# Altium schematic sheet Sheet1.SchDoc
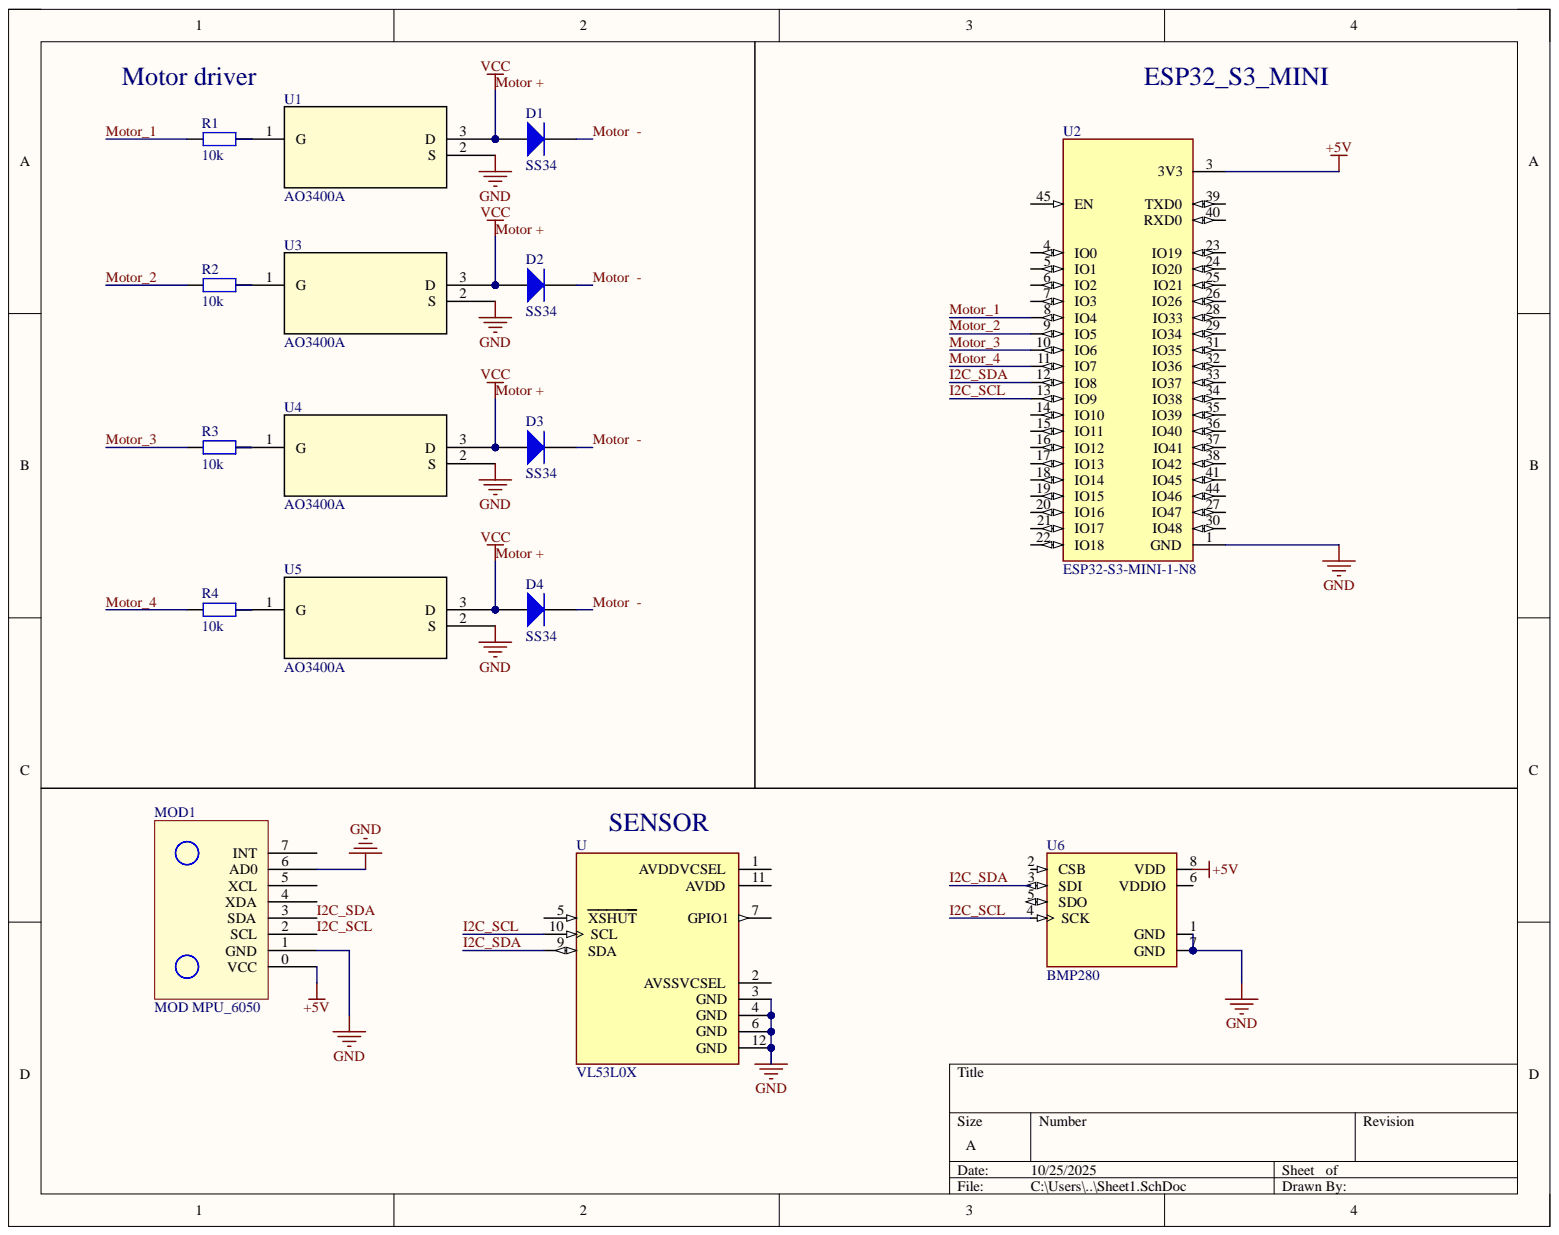
[diagram p0: Sheet1.SchDoc]

## Parts
D1  SS34  [DIODE SMA]
D2  SS34  [DIODE SMA]
D3  SS34  [DIODE SMA]
D4  SS34  [DIODE SMA]
MOD1  MOD MPU_6050  [MOD MPU-6050]
R1  10k  [tro smd 0603]
R2  10k  [tro smd 0603]
R3  10k  [tro smd 0603]
R4  10k  [tro smd 0603]
U  VL53L0X  [SENSOR_VL53L0X]
U1  AO3400A  [SOT-23-3L_AOS]
U2  ESP32-S3-MINI-1-N8  [XCVR_ESP32-S3-MINI-1-N8]
U3  AO3400A  [SOT-23-3L_AOS]
U4  AO3400A  [SOT-23-3L_AOS]
U5  AO3400A  [SOT-23-3L_AOS]
U6  BMP280  [XDCR_BMP280]

## Nets
+5V: MOD1.0(VCC) U2.3(3V3) U6.8(VDD)
GND: MOD1.1(GND) MOD1.6(AD0) U.3(GND) U.4(GND) U.6(GND) U.12(GND) U1.2 U2.1(GND) U3.2 U4.2 U5.2 U6.1(GND) U6.7(GND)
I2C_SCL: MOD1.2(SCL) U.10(SCL) U2.13(IO9) U6.4(SCK)
I2C_SDA: MOD1.3(SDA) U.9(SDA) U2.12(IO8) U6.3(SDI)
Motor  -: D1.2 D2.2 D3.2 D4.2
Motor +: D1.1 D2.1 D3.1 D4.1 U1.3 U3.3 U4.3 U5.3
Motor_1: R1.1 U2.8(IO4)
Motor_2: R2.1 U2.9(IO5)
Motor_3: R3.1 U2.10(IO6)
Motor_4: R4.1 U2.11(IO7)
NetR1_2: R1.2 U1.1
NetR2_2: R2.2 U3.1
NetR3_2: R3.2 U4.1
NetR4_2: R4.2 U5.1

Not connected: MOD1 4(XDA) 5(XCL) 7(INT); U 1(AVDDVCSEL) 2(AVSSVCSEL) 5(XSHUT) 7(GPIO1) 11(AVDD); U2 4(IO0) 5(IO1) 6(IO2) 7(IO3) 14(IO10) 15(IO11) 16(IO12) 17(IO13) 18(IO14) 19(IO15) 20(IO16) 21(IO17) 22(IO18) 23(IO19) 24(IO20) 25(IO21) 26(IO26) 27(IO47) 28(IO33) 29(IO34) 30(IO48) 31(IO35) 32(IO36) 33(IO37) 34(IO38) 35(IO39) 36(IO40) 37(IO41) 38(IO42) 39(TXD0) 40(RXD0) 41(IO45) 44(IO46) 45(EN); U6 2(CSB) 5(SDO) 6(VDDIO)

## Library data of the parts
D1-D4 = Diode SS34 ( 1N5822 ): Dán; Don_Vi: Con; Ma_Hang: MH002104; Ten_Linh_Kien: Diode SS34 3A 40V SMA ( 1N5822 SS34A); Chung_Loai: Dán; Component Kind: Standard; Library Name: Altium_Lib_by_KhueNguyenCreator.IntLib
R1-R4 = Tro 0603 1% 10k: Dán; Don_Vi: Con; Ma_Hang: MH006333; So_Chan: 2; Ten_Linh_Kien: Trở 0603 1% 10k; Chung_Loai: Dán; Component Kind: Standard; Library Name: Altium_Lib_by_KhueNguyenCreator.IntLib
U = VL53L0X: VL53L0X - Light, 3D Time-of-Flight _ToF_ Sensor Evaluation Board; MF: STMicroelectronics; Availability: Unavailable; Component Kind: Standard; Library Name: VL53L0X.IntLib; MP: VL53L0X; Package: None; Price: None; SnapEDA_Link: https://www.snapeda.com/parts/VL53L0X/STMicroelectronics/view-part/473967/?ref=snap
U1 U3-U5 = AO3400A: Description; Manufacturer_Name: Alpha and Omega Semiconductor; Component Kind: Standard; Library Name: AO3400A.IntLib; Manufacturer_Part_Number: AO3400A; RefDes: RefDes; Type: Type; Copyright: Copyright (C) 2025 Ultra Librarian. All rights reserved.
U2 = ESP32-S3-MINI-1-N8: MF: {'manufacturer': u'Espressif Systems'}; Availability: Unavailable; Component Kind: Standard; Library Name: ESP32-S3-MINI-1-N8.IntLib; MP: ESP32-S3-MINI-1-N8; Package: None; Price: None
U6 = BMP280: BMP280 Absolute Barometric Pressure Sensor for Battery Powered Devices 2 x 2.5mm; Availability: Unavailable; Component Kind: Standard; Library Name: BMP280.SchLib; MP: BMP280; Package: None; Price: None
MOD1 = MOD MPU_6050: Component Kind: Standard; Library Name: Altium_Lib_by_KhueNguyenCreator.IntLib
